# Altium schematic sheet AFE.SchDoc
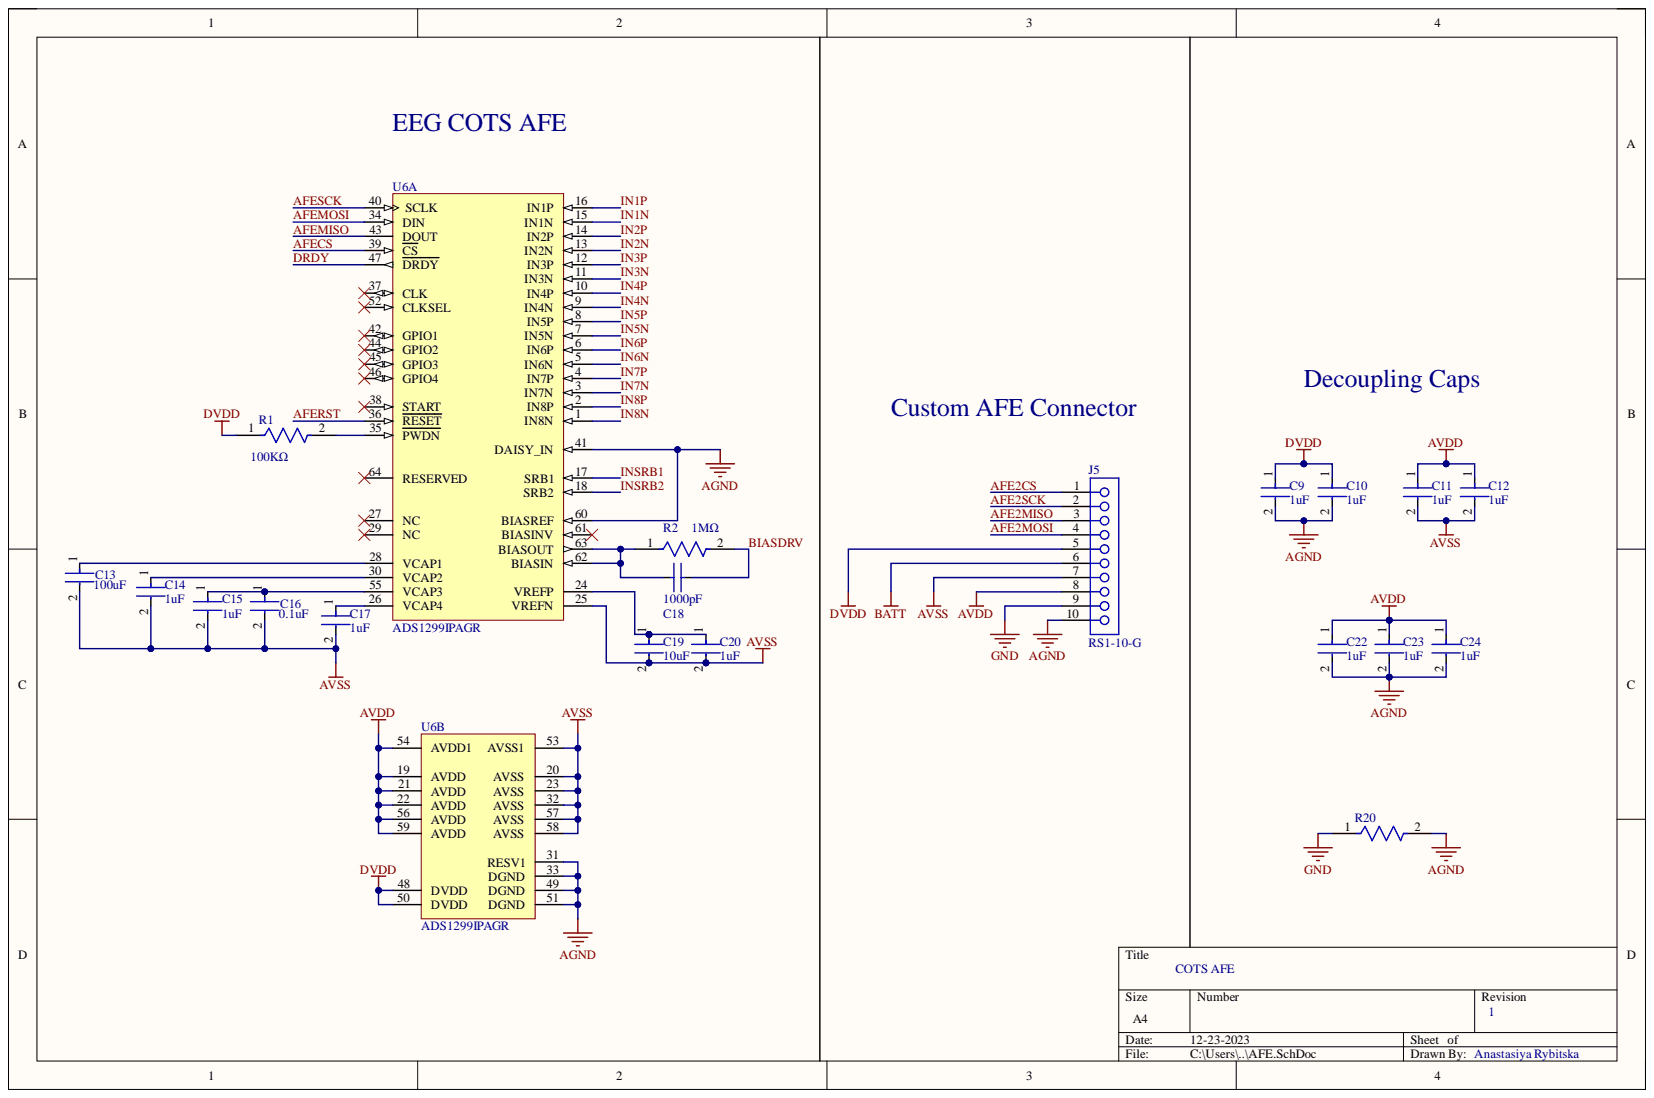
[diagram p0: AFE.SchDoc]

## Parts
C9  Capacitance: 1uF  [FP-CL10-IPC_C]  (hidden comment: CL10A105KA8NNNC)
C10  Capacitance: 1uF  [FP-CL10-IPC_C]  (hidden comment: CL10A105KA8NNNC)
C11  Capacitance: 1uF  [FP-CL10-IPC_C]  (hidden comment: CL10A105KA8NNNC)
C12  Capacitance: 1uF  [FP-CL10-IPC_C]  (hidden comment: CL10A105KA8NNNC)
C13  Capacitance: 100uF  [FP-GRM21B-0_2-e0_2_0_7-IPC_A]  (hidden comment: GRM21BR60E107ME15K)
C14  Capacitance: 1uF  [FP-CL10-IPC_C]  (hidden comment: CL10A105KA8NNNC)
C15  Capacitance: 1uF  [FP-CL10-IPC_C]  (hidden comment: CL10A105KA8NNNC)
C16  Capacitance: 0.1uF  [FP-0603-L_1_6_0_15-W_0_81-MFG]  (hidden comment: KGM15BR71H104JT)
C17  Capacitance: 1uF  [FP-CL10-IPC_C]  (hidden comment: CL10A105KA8NNNC)
C18  Capacitance: 1000pF  [CAP_0603_S_WAL]  (hidden comment: 0603N102F500CT)
C19  Capacitance: 10uF  [FP-CL10-IPC_C]  (hidden comment: CL10A106MQ8NNNC)
C20  Capacitance: 1uF  [FP-CL10-IPC_C]  (hidden comment: CL10A105KA8NNNC)
C22  Capacitance: 1uF  [FP-CL10-IPC_C]  (hidden comment: CL10A105KA8NNNC)
C23  Capacitance: 1uF  [FP-CL10-IPC_C]  (hidden comment: CL10A105KA8NNNC)
C24  Capacitance: 1uF  [FP-CL10-IPC_C]  (hidden comment: CL10A105KA8NNNC)
J5  RS1-10-G  [61301011821]
R1  Resistance: 100KΩ  [FP-RMCF0603-IPC_C]  (hidden comment: RMCF0603FT100K)
R2  Resistance: 1MΩ  [FP-ERJ3EK-IPC_C]  (hidden comment: ERJ-3EKF1004V)
R20  RMCF0603ZT0R00  [FP-RMCF0603-MFG]  (value not drawn)
U6  ADS1299IPAGR  [PAG0064A_M]  (parts A,B)

## Nets
AGND: C9.2 C10.2 C22.2 C23.2 C24.2 J5.10 R20.2 U6.31(RESV1) U6.33(DGND) U6.41(DAISY_IN) U6.49(DGND) U6.51(DGND) U6.60(BIASREF)
AVDD: C11.1 C12.1 C22.1 C23.1 C24.1 J5.8 U6.19(AVDD) U6.21(AVDD) U6.22(AVDD) U6.54(AVDD1) U6.56(AVDD) U6.59(AVDD)
AVSS: C11.2 C12.2 C13.2 C14.2 C15.2 C16.2 C17.2 C19.2 C20.2 J5.7 U6.20(AVSS) U6.23(AVSS) U6.25(VREFN) U6.32(AVSS) U6.53(AVSS1) U6.57(AVSS) U6.58(AVSS)
BATT: J5.6
DVDD: C9.1 C10.1 J5.5 R1.1 U6.48(DVDD) U6.50(DVDD)
GND: J5.9 R20.1
AFE2CS: J5.1
AFE2MISO: J5.3
AFE2MOSI: J5.4
AFE2SCK: J5.2
AFECS: U6.39(CS)
AFEMISO: U6.43(DOUT)
AFEMOSI: U6.34(DIN)
AFERST: U6.36(RESET)
AFESCK: U6.40(SCLK)
BIASDRV: C18.2 R2.2
DRDY: U6.47(DRDY)
IN1N: U6.15(IN1N)
IN1P: U6.16(IN1P)
IN2N: U6.13(IN2N)
IN2P: U6.14(IN2P)
IN3N: U6.11(IN3N)
IN3P: U6.12(IN3P)
IN4N: U6.9(IN4N)
IN4P: U6.10(IN4P)
IN5N: U6.7(IN5N)
IN5P: U6.8(IN5P)
IN6N: U6.5(IN6N)
IN6P: U6.6(IN6P)
IN7N: U6.3(IN7N)
IN7P: U6.4(IN7P)
IN8N: U6.1(IN8N)
IN8P: U6.2(IN8P)
INSRB1: U6.17(SRB1)
INSRB2: U6.18(SRB2)
NetC13_1: C13.1 U6.28(VCAP1)
NetC14_1: C14.1 U6.30(VCAP2)
NetC15_1: C15.1 C16.1 U6.55(VCAP3)
NetC17_1: C17.1 U6.26(VCAP4)
NetC18_1: C18.1 R2.1 U6.62(BIASIN) U6.63(BIASOUT)
NetC19_1: C19.1 C20.1 U6.24(VREFP)
NetR1_2: R1.2 U6.35(PWDN)

Not connected: U6 27(NC) 29(NC) 37(CLK) 38(START) 42(GPIO1) 44(GPIO2) 45(GPIO3) 46(GPIO4) 52(CLKSEL) 61(BIASINV) 64(RESERVED)

## Library data of the parts
C9-C12 C14 C15 C17 C20 C22-C24 = CMP-13271-004127-1: Cap Ceramic 1uF 25V X5R ±10% Pad SMD 0603 +85°C T/R; Case Code (Imperial): 0603; Case Code (Metric): 1608; Case/Package: 0603; Component Kind: Standard; Contact Plating: Tin; Depth: 0.8mm; Dielectric: X5R; Height: 0.8mm; Lead Free: Lead Free; Length: 1.6mm; Library Name: Altium Content Vault; Material: Ceramic; Max Operating Temperature: 85°C; Min Operating Temperature: -55°C; Mount: Surface Mount; Package Quantity: 1; Packaging: Tape and Reel; Radiation Hardening: No; Thickness: 0.035inch; Tolerance: 10%; Voltage: 25V; Voltage Rating: 25V; Voltage Rating (DC): 25V; Width: 0.031inch
C13 = CMP-06035-026524-1: Chip Multilayer Ceramic Capacitors for General Purpose, 0805, 100uF, X5R, 15%, 20%, 2.5V; Component Kind: Standard; Dielectric: X5R; Length: 2mm; Library Name: Altium Content Vault; Thickness: 1.25mm; Tolerance: 20%; Voltage Rating (DC): 2.5V; Width: 1.25mm
C16 = CMP-07738-136560482-1: KGM15BR71H104JT Cap Cer 0.1UF 50V X7R 0603; Material: Ceramic; Tolerance: 5%; Case/Package: 0603; Component Kind: Standard; Height: 940µm; Library Name: Altium Content Vault; Max Operating Temperature: 125°C; Min Operating Temperature: -55°C; NexarPartId: 136560482; Voltage Rating: 50V
C18 = 0603N102F500CT: No Description Available; Mfr_Name: Walsin; Type: DEV; Component Kind: Standard; Library Name: Schlib1.SchLib; Manufacturer_Part_Number: 0603N102F500CT; RefDes: RefDes; Copyright: Copyright (C) 2023 Ultra Librarian. All rights reserved.
C19 = CMP-13271-001938-1: Cap Ceramic 10uF 6.3V X5R ±20% Pad SMD 0603 +85°C T/R; Case Code (Imperial): 0603; Case Code (Metric): 1608; Case/Package: 0603; Component Kind: Standard; Contact Plating: Tin; Depth: 0.8mm; Dielectric: X5R; Height: 0.8mm; Length: 1.6mm; Library Name: Altium Content Vault; Max Operating Temperature: 85°C; Min Operating Temperature: -55°C; Mount: Surface Mount; Package Quantity: 1; Packaging: Tape and Reel; Radiation Hardening: No; Thickness: 0.035inch; Tolerance: 20%; Voltage: 6.3V; Voltage Rating: 6.3V; Width: 0.031inch
J5 = CMP-1502-01332-2: NexarPartId: 18818160; Connector Type: Header, Socket; Contact Resistance: 20mΩ; Current Rating: 3A; Gender: Female; Insulation: Insulated; Insulation Resistance: 1GΩ; Number of Contacts: 10; Operating Supply Voltage: 250V; Pitch: 2.54mm; Color: Black; Component Kind: Standard; Contact Gender: Female; Contact Material: Copper; Contact Plating: Gold; Flammability Rating: UL94 V-0; Height: 8.5mm; Housing Material: PBT; Lead Free: Lead Free; Lead Pitch: 2.54mm; Length: 25.9mm; Library Name: Altium Content Vault; Max Operating Temperature: 125°C; Min Operating Temperature: -40°C; Mount: Through Hole; Number of Pins: 10; Number of Positions: 10; Number of Rows: 1; Orientation: Vertical; REACH SVHC: Unknown; RoHS: Compliant; Termination: Through Hole; Voltage Rating: 250V; Width: 25.9mm
R1 = CMP-2000-06989-2: 100kΩ ±1% 0.1W 0603 Thick Film Chip Resistor AEC-Q200 compliant; Max Power Dissipation: 100mW; Temperature Coefficient: 100ppm/°C; Working Voltage: 75V; Case Code (Imperial): 0603; Case Code (Metric): 1608; Case/Package: 0603; Component Kind: Standard; Construction: Rectangular; Contact Plating: Tin; Depth: 0.8mm; Features: Automotive AEC-Q200; Height: 0.022inch; Lead Free: Lead Free; Length: 1.55mm; Library Name: Altium Content Vault; Max Operating Temperature: 155°C; Military Standard: Not; Min Operating Temperature: -55°C; Mount: Surface Mount; Number of Terminations: 2; Packaging: Tape and Reel; Power Rating: 100mW; Radiation Hardening: No; Resistor Type: Thick Film; RoHS Compliant: Yes; Series: RMCF; Tolerance: 1%; Width: 0.031inch
R2 = CMP-1012-00849-3: RES SMD 1M OHM 1% 1/10W 0603; Max Power Dissipation: 100mW; Temperature Coefficient: 100ppm/°C; Voltage Rating: 75V; Case Code (Imperial): 0603; Case Code (Metric): 1608; Case/Package: 0603; Component Kind: Standard; Construction: Rectangular; Contact Plating: Tin; Depth: 0.8mm; Features: Automotive AEC-Q200; Height: 0.022inch; Lead Free: Lead Free; Length: 1.6mm; Library Name: Altium Content Vault; Max Operating Temperature: 155°C; Military Standard: Not; Min Operating Temperature: -55°C; Mount: Surface Mount; Number of Pins: 2; Number of Terminations: 2; Package Quantity: 1; Packaging: Tape and Reel; Power Rating: 100mW; Radiation Hardening: No; REACH SVHC: No SVHC; Resistance Tolerance: 1%; Resistor Type: Thick Film; RoHS Compliant: Yes; Series: ERJ; Size Code: 0603; Termination: SMD/SMT; Tolerance: 1%; Voltage Rating (DC): 75V; Width: 0.8mm
R20 = CMP-26527-000011-1: 0 Ohms Jumper 0.1W, 1/10W Chip Resistor 0603 (1608 Metric) Automotive AEC-Q200 Thick Film; Manufacturer: Stackpole; Automotive Grade: Grade 0; Resistance: 0mΩ; Value: 0mΩ; Voltage Rating: 75V; Automotive: Yes; Category: Res; Component Kind: Standard; ComponentLink2Description: Datasheet; ComponentLink2URL: https://www.seielect.com/catalog/sei-rmcf_rmcp.pdf; Composition: Thick Film; Device Class L1: Passive Components; Device Class L2: Resistors; Device Class L3: Chip SMD Resistors; Digikey Description: RES 0 OHM JUMPER 1/10W 0603; Height: 0.55mm; Ipc Land Pattern Name: RESC15580X45; Lead Free: Yes; Library Name: Altium Content Vault; Manufacturer Part Number: RMCF0603ZT0R00; Octopart: https://octopart.com/rmcf0603zt0r00-stackpole+electronics-19213618; Package: RMCF0603; Power Rating: 0.1W; RoHS: TRUE; Series: RMCF; Temperature: -55°C to +155°C; Temperature Range High: +155°C; Temperature Range Low: -55°C
U6 = CMP-0841-00001-2: Low-Noise, 8-Channel, 24-Bit Analog Front-End for Biopotential Measurement, 16 KSPS, -40 to 85 degC, 64-Pin PQFP (PAG-64), RoHS, Tape and Reel; Manufacturer: Texas Instruments; # Input Channels: 8; Architecture: Delta-Sigma; Interface: Serial SPI; Packing: PAG0064A; Reference Mode: Int, Ext; Resolution (Bits): 24; Sample Rate (max) (KSPS): 16; Component Kind: Standard; ComponentLink1Description: Manufacturer URL; ComponentLink1URL: http://www.ti.com/; ComponentLink2Description: Datasheet; ComponentLink2URL: http://www.ti.com/lit/ds/symlink/ads1299.pdf; ComponentLink3Description: Package Specification; ComponentLink3URL: http://www.ti.com/lit/ml/mtqf006a/mtqf006a.pdf; DatasheetVersion: SBAS499A; Library Name: Altium Content Vault; Mounting Technology: Surface Mount; Operating Temperature Range (C): -40 to 85; PackageDescription: 64-Pin Plastic Quad Flatpack, Body 10 x 10 mm, Pitch 0.5 mm; PackageReference: PAG-64; PackageVersion: 4040282/C; PartNumber: ADS1299IPAGR; RoHS: TRUE
